# Altium schematic sheet S3Remote4R3_V2_power.SchDoc
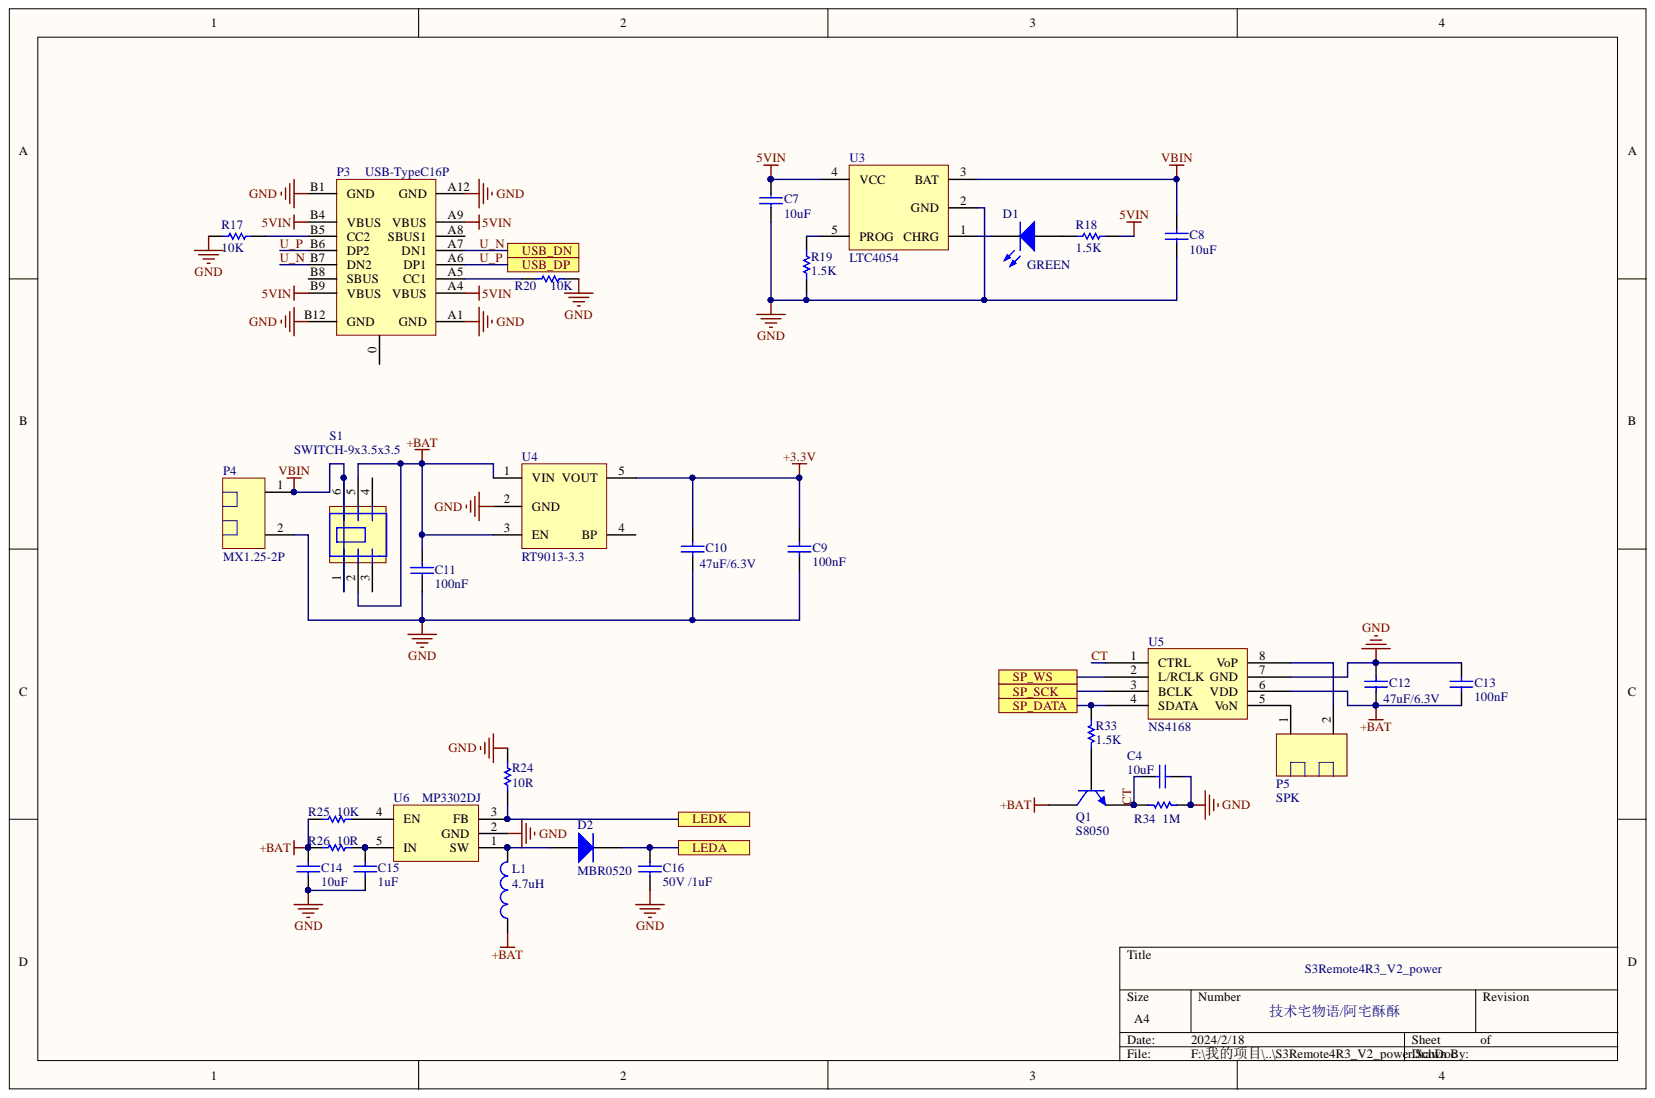
[diagram p0: S3Remote4R3_V2_power.SchDoc]

## Parts
C4  10uF  [C0603B]
C7  10uF  [C0603B]
C8  10uF  [C0603B]
C9  100nF  [C0603B]
C10  47uF/6.3V  [C1206B]
C11  100nF  [C0603B]
C12  47uF/6.3V  [C1206B]
C13  100nF  [C0603B]
C14  10uF  [C0603B]
C15  1uF  [C0603B]
C16  50V /1uF  [C0603B]
D1  GREEN  [LED0603-B]
D2  MBR0520  [DIO-DO123]
L1  4.7uH  [L-CD43]
P3  USB-TypeC16P  [USB-TypeC-16P1]
P4  MX1.25-2P  [MX1.25WS-2P]
P5  SPK  [MX1.25WS-2P]
Q1  S8050  [SOT-23-3]
R17  10K  [RES0603]
R18  1.5K  [RES0603]
R19  1.5K  [RES0603]
R20  10K  [RES0603]
R24  10R  [RES0805]
R25  10K  [RES0603]
R26  10R  [RES0805]
R33  1.5K  [RES0603]
R34  1M  [RES0603]
S1  SWITCH-9x3.5x3.5  [SW-SMD-9x3.5x3.5]
U3  LTC4054  [SOT23-5]
U4  RT9013-3.3  [SOT23-5]
U5  NS4168  [SOP8B-G]
U6  MP3302DJ  [SOT23-5]

## Ports
LEDA, LEDK, SP_DATA, SP_SCK, SP_WS, USB_DN, USB_DP

## Nets
5VIN: C7.2 P3.A4(VBUS) P3.A9(VBUS) P3.B4(VBUS) P3.B9(VBUS) R18.2 U3.4(VCC)
+3.3V: C9.2 C10.2 U4.5(VOUT)
+BAT: C11.2 C12.1 C13.2 C14.2 L1.1 Q1.2 R25.2 R26.2 S1.2 S1.5 U4.1(VIN) U4.3(EN) U5.6(VDD)
GND: C4.2 C7.1 C8.1 C9.1 C10.1 C11.1 C12.2 C13.1 C14.1 C15.1 C16.1 P3.A1(GND) P3.A12(GND) P3.B1(GND) P3.B12(GND) P4.2 R17.2 R19.2 R20.1 R24.1 R34.1 U3.2(GND) U4.2(GND) U5.7(GND) U6.2(GND)
VBIN: C8.2 P4.1 S1.1 S1.6 U3.3(BAT)
CT: C4.1 Q1.3 R34.2 U5.1(CTRL)
LEDA: C16.2 D2.1 ; port LEDA
LEDK: R24.2 U6.3(FB) ; port LEDK
SP_DATA: R33.2 U5.4(SDATA) ; port SP_DATA
SP_SCK: U5.3(BCLK) ; port SP_SCK
SP_WS: U5.2(L/RCLK) ; port SP_WS
U_N: P3.A7(DN1) P3.B7(DN2) ; port USB_DN
U_P: P3.A6(DP1) P3.B6(DP2) ; port USB_DP
NetC15_2: C15.2 R26.1 U6.5(IN)
NetD1_1: D1.1 U3.1(CHRG)
NetD1_2: D1.2 R18.1
NetD2_2: D2.2 L1.2 U6.1(SW)
NetP3_A5: P3.A5(CC1) R20.2
NetP3_B5: P3.B5(CC2) R17.1
NetP5_1: P5.1 U5.5(VoN)
NetP5_2: P5.2 U5.8(VoP)
NetQ1_1: Q1.1 R33.1
NetR19_1: R19.1 U3.5(PROG)
NetR25_1: R25.1 U6.4(EN)

Not connected: P3 0 A8(SBUS1) B8(SBUS); S1 3 4; U4 4(BP)

## Library data of the parts
C4 C7-C16 = CAP-Nonpolarity: CAP; Component Kind: Standard; Library Name: Johan.IntLib
D1 = LED: Component Kind: Standard; Description: LED; Library Name: Johan.IntLib
D2 = DIODE: 二极管; Component Kind: Standard; Library Name: Johan.IntLib
L1 = INDUCTOR: 电感; Component Kind: Standard; Library Name: Johan.IntLib
P3 = CONN-USB-TypeC-16P: TypeC-16P; Component Kind: Standard; Library Name: Johan.IntLib
P5 = MX1.25-2P: Component Kind: Standard; Library Name: MX.IntLib
Q1 = TRIODE-NPN: SOT23; Component Kind: Standard; Library Name: Johan.IntLib
R17-R20 R24-R26 R33 R34 = RES: Component Kind: Standard; Description: RES; Library Name: Johan.IntLib
S1 = SWITCH-9x3.5x3.5: SMD6; Component Kind: Standard; Library Name: Johan.IntLib
U3 = IC-LTC4054: SOT23-5; Component Kind: Standard; Library Name: Johan.IntLib
U4 = IC-RT9193: SOT23-5; Component Kind: Standard; Library Name: Johan.IntLib
U5 = IC-NS4168: IIS数字功放; Component Kind: Standard; Library Name: Johan.IntLib
U6 = IC-MP3302DJ: LED背光升压驱动芯片，SOT23-6; Component Kind: Standard; Library Name: Johan.IntLib
P4 = MX1.25-2P: Component Kind: Standard; Library Name: MX.IntLib
